# Altium schematic sheet PAGE2-ESP12_CORE.SchDoc(PAGE2-ESP12_CORE)
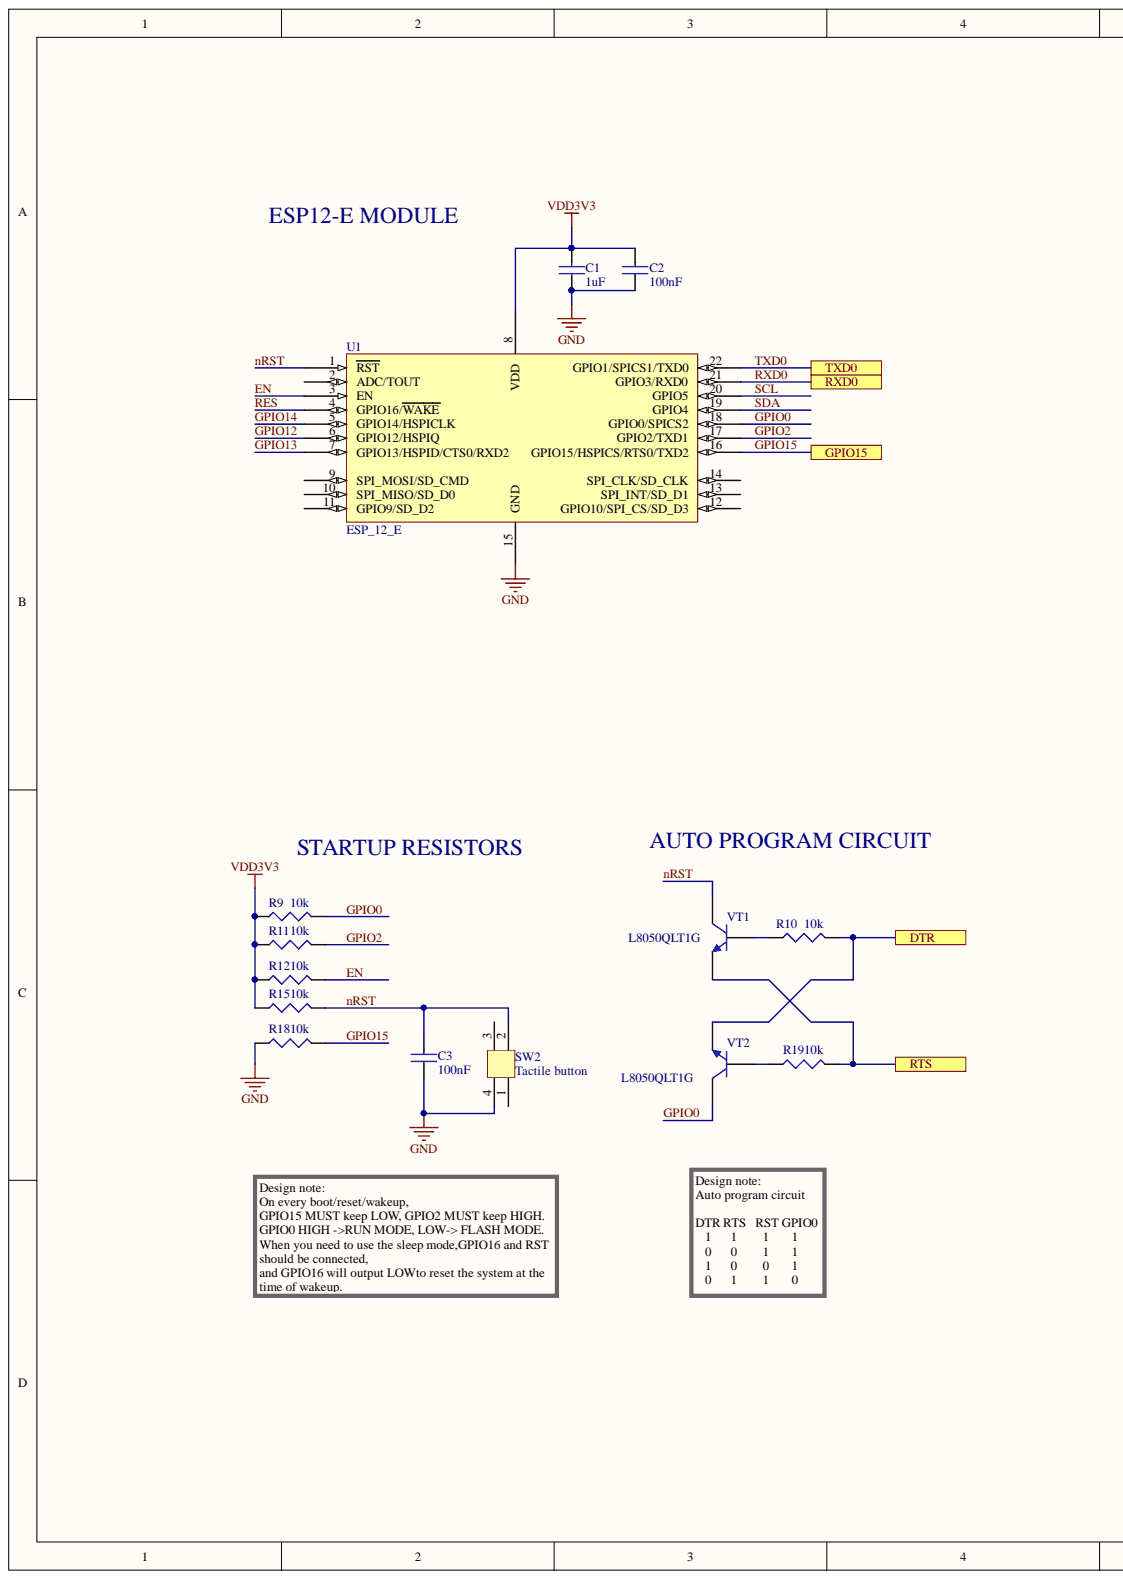
[diagram p0: PAGE2-ESP12_CORE.SchDoc, left]
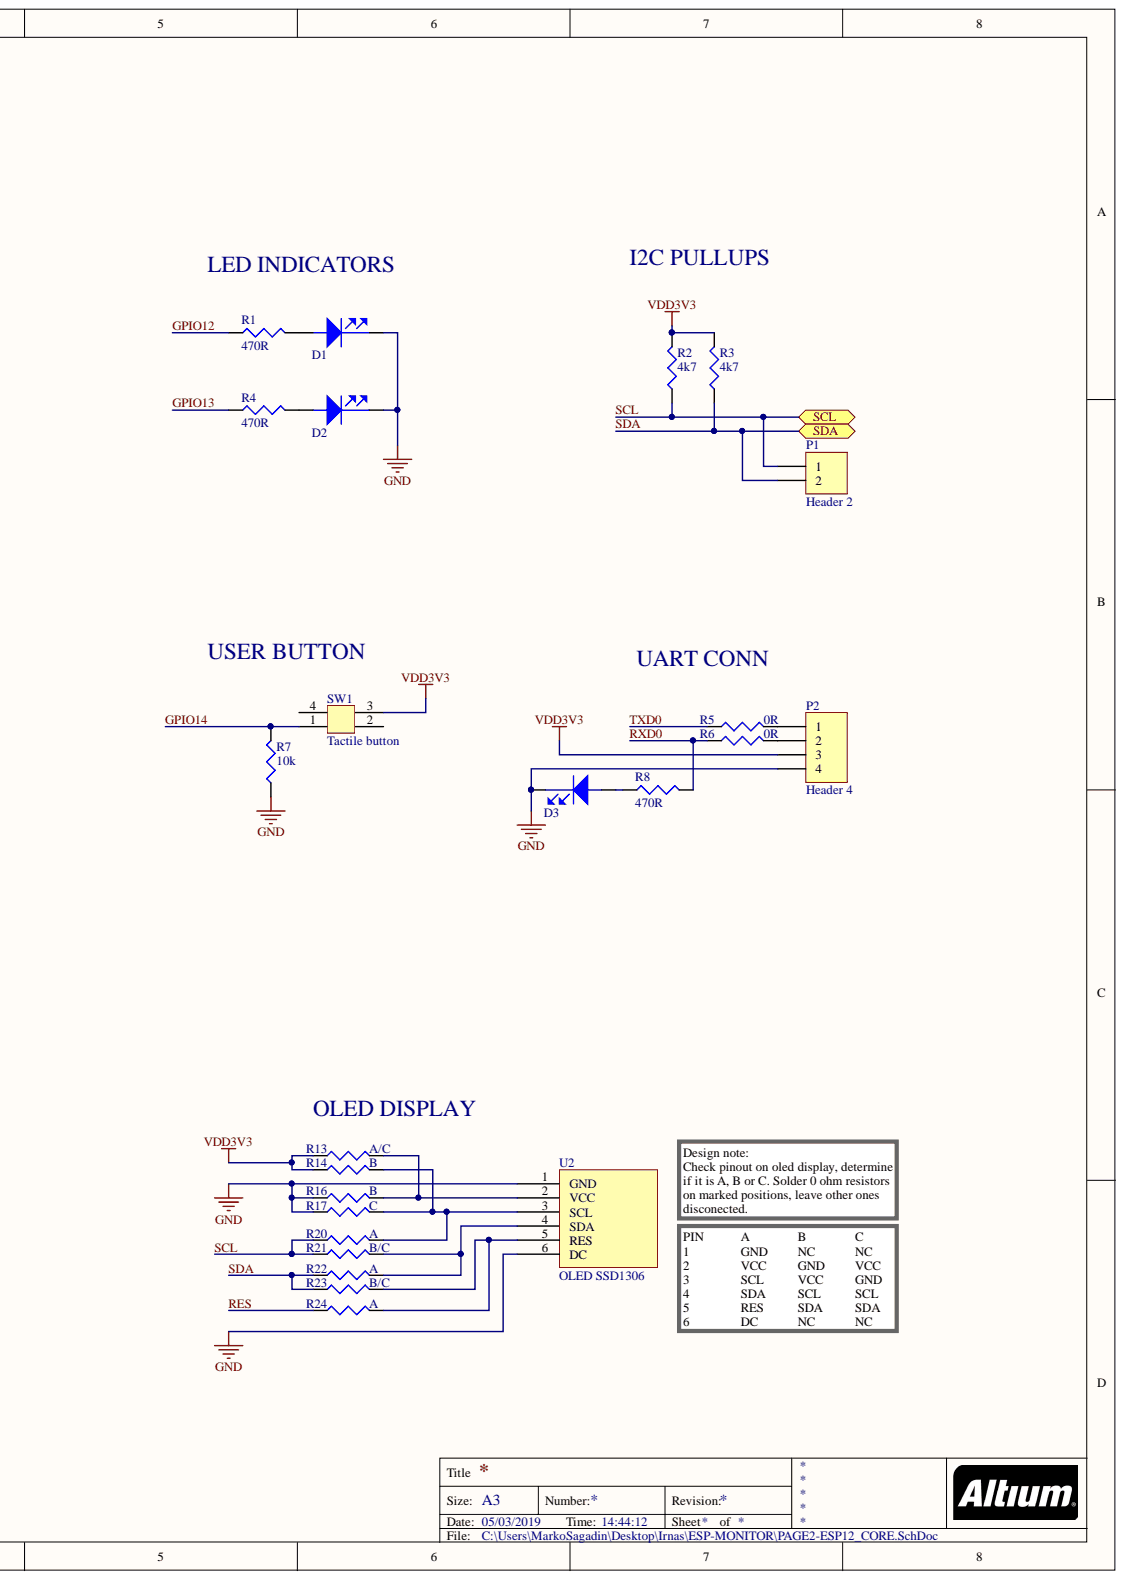
[diagram p1: PAGE2-ESP12_CORE.SchDoc, right]

## Parts
C1  1uF  [CAP0603]
C2  100nF  [CAP0603]
C3  100nF  [CAP0603]
D1  LED RED  [LED0603]  (value not drawn)
D2  LED RED  [LED0603]  (value not drawn)
D3  LED RED  [LED0603]  (value not drawn)
P1  Header 2  [HDR1X2]
P2  Header 4  [HDR1X4]
R1  470R  [RES0603]
R2  4k7  [RES0603]
R3  4k7  [RES0603]
R4  470R  [RES0603]
R5  0R  [RES0603]
R6  0R  [RES0603]
R7  10k  [RES0603]
R8  470R  [RES0603]
R9  10k  [RES0603]
R10  10k  [RES0603]
R11  10k  [RES0603]
R12  10k  [RES0603]  (value not drawn)
R13  A/C  [RES0603]
R14  B  [RES0603]
R15  10k  [RES0603]  (value not drawn)
R16  B  [RES0603]
R17  C  [RES0603]
R18  10k  [RES0603]  (value not drawn)
R19  10k  [RES0603]  (value not drawn)
R20  A  [RES0603]
R21  B/C  [RES0603]
R22  A  [RES0603]
R23  B/C  [RES0603]
R24  A  [RES0603]
SW1  Tactile button  [Tactile button]
SW2  Tactile button  [Tactile button]
U1  ESP_12_E  [ESP_12_E]
U2  OLED SSD1306  [OLED SSD1306]
VT1  L8050QLT1G  [SMT_TRIODE_NPN]
VT2  L8050QLT1G  [SMT_TRIODE_NPN]

## Ports
DTR, GPIO15, RTS, RXD0, SCL, SDA, TXD0

## Nets
GND: C1.1 C2.1 C3.1 D1.1 D2.1 D3.1 P2.4 R7.1 R16.1 R17.1 R18.1 SW2.4 U1.15(GND) U2.1(GND) U2.6(DC)
VDD3V3: C1.2 C2.2 P2.3 R2.2 R3.2 R9.1 R11.1 R12.1 R13.1 R14.1 R15.1 SW1.3 U1.8(VDD)
DTR: R10.2 VT2.2 ; port DTR
EN: R12.2 U1.3(EN)
GPIO0: R9.2 U1.18(GPIO0/SPICS2) VT2.3
GPIO2: R11.2 U1.17(GPIO2/TXD1)
GPIO12: R1.1 U1.6(GPIO12/HSPIQ)
GPIO13: R4.1 U1.7(GPIO13/HSPID/CTS0/RXD2)
GPIO14: R7.2 SW1.1 U1.5(GPIO14/HSPICLK)
GPIO15: R18.2 U1.16(GPIO15/HSPICS/RTS0/TXD2) ; port GPIO15
nRST: C3.2 R15.2 SW2.2 U1.1(RST) VT1.3
RES: R24.1 U1.4(GPIO16/WAKE)
RTS: R19.2 VT1.2 ; port RTS
RXD0: R6.1 R8.2 U1.21(GPIO3/RXD0) ; port RXD0
SCL: P1.1 R2.1 R20.1 R21.1 U1.20(GPIO5) ; port SCL
SDA: P1.2 R3.1 R22.1 R23.1 U1.19(GPIO4) ; port SDA
TXD0: R5.1 U1.22(GPIO1/SPICS1/TXD0) ; port TXD0
NetD1_2: D1.2 R1.2
NetD2_2: D2.2 R4.2
NetD3_2: D3.2 R8.1
NetP2_1: P2.1 R5.2
NetP2_2: P2.2 R6.2
NetR10_1: R10.1 VT1.1
NetR13_2: R13.2 R16.2 U2.2(VCC)
NetR14_2: R14.2 R17.2 R20.2 U2.3(SCL)
NetR19_1: R19.1 VT2.1
NetR21_2: R21.2 R22.2 U2.4(SDA)
NetR23_2: R23.2 R24.2 U2.5(RES)

Not connected: SW1 2 4; SW2 1 3; U1 2(ADC/TOUT) 9(SPI_MOSI/SD_CMD) 10(SPI_MISO/SD_D0) 11(GPIO9/SD_D2) 12(GPIO10/SPI_CS/SD_D3) 13(SPI_INT/SD_D1) 14(SPI_CLK/SD_CLK)

## Library data of the parts
C1 = 1uF: MURATA - GRM188R61H105KAALD - CAP, MLCC, X5R, 1UF, 50V, 0603; Voltage Rating: 50; SVHC: No SVHC (17-Dec-2015); Product Range: GRM Series; Dielectric Characteristic: X5; Ceramic Capacitor Case: 0603 [1608 Metric]; Capacitor Terminals: SMD<!--FANDB--><div class="features-and-benefits-container"><div class="features-and-benefits-description"><span class="features-benefits-value">The Murata GRM series capacitors are Multilayer Ceramic Chip Capacitors (MLCC) used for general purpose applications. These MLCC capacitors are classified into temperature compensating and high dielectric constant types depending on the change in electrostatic capacitance due to change in temperature. The temperature compensating capacitors feature a small rate of change in electrostatic capacitance as the temperature changes and are used for applications such as filters and high frequency circuit matching. The high dielectric constant capacitors use materials with a high dielectric constant and feature a large electrostatic capacitance. Typical applications are power supply decoupling and smoothing circuits.</span></div><div class="features-and-benefits-list"><ul class="features-and-benefits-list-list"><li class="features-and-benefits-list-item">Large capacity and small size in a multilayer structure</li><li class="features-and-benefits-list-item">Capacitance value ranging from 0.1pF to 220?F</li><li class="features-and-benefits-list-item">Tin plated external electrodes, excellent solderability</li><li class="features-and-benefits-list-item">Provides good high frequency characteristics</li><li class="features-and-benefits-list-item">Excellent pulse response</li><li class="features-and-benefits-list-item">High reliability</li><li class="features-and-benefits-list-item">Capacitor size varies from 0.4×0.2mm to 5.7×5.0mm</li><li class="features-and-benefits-list-item">Rated voltage of 2.5VDC to 3.15; Capacitance Tolerance ±: ± 10%; Capacitance: 1; Supplier Part Number 1: 1845736; Supplier 1: Farnell; Stock 1: 62682; RoHS: YES; Pricing 1: 10=0.093, 100=0.062, 500=0.0507, 1000=0.0465, 2000=0.0429, 4000=0.0399, 20000=0.0372, 40000=0.0365 (GBP); Packaging: Cut Tape; Operating Temperature Min: -55; Operating Temperature Max: 85; MSL: -; Manufacturer Part Number: GRM188R61H105KAALD; Manufacturer: MURATA; Library Name: Capacitors.IntLib; ComponentLink1URL: http://www.farnell.com/datasheets/2048611.pdf; ComponentLink1Description: http://www.farnell.com/datasheets/2048611.pdf; Component Kind: Standard; Capacitance Tolerance: ± 10%; Automotive Qualification Standard: -
C2 C3 = 100nF: MURATA - GRM188R71C104KA01J - CAP, MLCC, X7R, 0.1UF, 16V, 0603; Voltage Rating: 16; SVHC: No SVHC (20-Jun-2016; Product Range: GRM Series; Dielectric Characteristic: X7; Ceramic Capacitor Case: 0603 [1608 Metric]; Capacitance Tolerance: ± 10%; Capacitance: 0.1; Supplier Part Number 1: 2688519; Supplier 1: Farnell; Stock 1: 18297; RoHS: YES; Pricing 1: 10=0.0145, 100=0.0126, 500=0.0081, 2500=0.0059, 5000=0.0052, 10000=0.0046, 50000=0.0036, 100000=0.0035 (GBP); Packaging: Cut Tape; Operating Temperature Min: -55; Operating Temperature Max: 125; MSL: MSL 1 - Unlimited; Manufacturer Part Number: GRM188R71C104KA01J; Manufacturer: MURATA; Library Name: Capacitors.IntLib; ComponentLink1URL: http://www.farnell.com/datasheets/2189124.pdf; ComponentLink1Description: http://www.farnell.com/datasheets/2189124.pdf; Component Kind: Standard; Automotive Qualification Standard: -
D1-D3 = LED RED: BROADCOM LIMITED - HSMS-C190 - LED, RED, 10MCD, 626NM; Wavelength Typ: 626; Viewing Angle: 170°; SVHC: No SVHC (17-Dec-2015)<!--FANDB--><div class="features-and-benefits-container"><div class="features-and-benefits-description"><span class="features-benefits-value">The HSMS-C190 is a HER SMD Chip LED with untinted/diffused lens, made of GaP. It is designed in an industry standard package 1.6 x 0.8mm footprint with 0.8mm profile. It is compatible with IR reflow solder processes. The small size and wide viewing angle make this LED prime choices for backlighting applications and front panel illumination especially where space is a premium.</span></div><div class="features-and-benefits-list"><ul class="features-and-benefits-list-list"><li class="features-and-benefits-list-item">Small size</li><li class="features-and-benefits-list-item">Industry standard footprint</li><li class="features-and-benefits-list-item">Compatible with IR solder</li><li class="features-and-benefits-list-item">Diffused optics</li><li class="features-and-benefits-list-item">-40 to 85; Luminous Intensity: 10; Lens Shape: Rectangular; LED Mounting: SMD; Forward Voltage: 2.1; Forward Current If: 20; Supplier Part Number 1: 2497356; Supplier 1: Farnell; Stock 1: 7534; RoHS: YES; Product Range: -; Pricing 1: 1=0.361, 10=0.256, 50=0.235, 100=0.106, 200=0.101 (GBP); Packaging: Cut Tape; MSL: -; Manufacturer Part Number: HSMS-C190; Manufacturer: BROADCOM LIMITED; Library Name: Diodes.IntLib; LED Colour: Red; ComponentLink3URL: http://www.farnell.com/datasheets/2165483.pdf; ComponentLink3Description: http://www.farnell.com/datasheets/2165483.pdf; ComponentLink2URL: http://www.farnell.com/datasheets/1681524.pdf; ComponentLink2Description: http://www.farnell.com/datasheets/1681524.pdf; ComponentLink1URL: http://www.farnell.com/datasheets/313031.pdf; ComponentLink1Description: http://www.farnell.com/datasheets/313031.pdf; Component Kind: Standard; Bulb Size: -; Automotive Qualification Standard: -
P1 = Header 2: Header, 2-Pin; Signal Integrity: Connector; Library Name: Miscellaneous Connectors.IntLib; LatestRevisionNote: Re-released for DXP Platform.; LatestRevisionDate: 17-Jul-2002; Component Kind: Standard; Publisher: Altium Limited
P2 = Header 4: Header, 4-Pin; Signal Integrity: Connector; Library Name: Miscellaneous Connectors.IntLib; LatestRevisionNote: Re-released for DXP Platform.; LatestRevisionDate: 17-Jul-2002; Component Kind: Standard; Publisher: Altium Limited
R1 R4 R8 = 470R: MULTICOMP - MCMR06X471 JTL - RES, CERAMIC, 470R, 5%, 0.1W, 0603; Supplier Part Number 1: 2073513; Supplier 1: Farnell; Stock 1: 3786; RoHS: Y-EX; Pricing 1: 10=0.0089, 100=0.0076, 500=0.0063, 1000=0.0049, 2500=0.0033, 5000=0.0024, 25000=0.0016, 50000=0.0013 (GBP); Manufacturer Part Number: MCMR06X471 JTL; Manufacturer: MULTICOMP; Library Name: Resistors.IntLib; ComponentLink1URL: http://www.farnell.com/datasheets/1716707.pdf; ComponentLink1Description: http://www.farnell.com/datasheets/1716707.pdf; Component Kind: Standard
R2 R3 = 4k7: MULTICOMP - MCMR06X4701FTL - RES, CERAMIC, 4K7, 1%, 0.1W, 0603; Voltage Rating: 75; Temperature Coefficient: ± 100; SVHC: No SVHC (12-Jan-2017)<!--FANDB--><div class="features-and-benefits-container"><div class="features-and-benefits-description"><span class="features-benefits-value">The Multicomp MCMR series resistors are automotive grade, anti sulphured thick film chip resistor. The resistance range includes E24 and E96 decade values from 1ohm to 10Mohm. These resistors are constructed on top of a high grade ceramic body.</span></div><div class="features-and-benefits-list"><ul class="features-and-benefits-list-list"><li class="features-and-benefits-list-item">EIA 0402, 0603, 0805, 1206 chip sizes available</li><li class="features-and-benefits-list-item">High reliability and stability</li><li class="features-and-benefits-list-item">Automotive grade AEC-Q200 compliant</li><li class="features-and-benefits-list-item">Complaint with (IEC 60068-1) 55/155/56 climatic category</li><li class="features-and-benefits-list-item">Maximum operation voltage of 50V, 75V, 150V and 200V</li><li class="features-and-benefits-list-item">Power rating of 62.5mW, 100mW, 125mW and 250mW</li><li class="features-and-benefits-list-item">Available in ±1% and ±5% tolerance</li><li class="features-and-benefits-list-item">Temperature coefficient (TCR) of +200ppm/°C, +100ppm/°C and -200ppm/°C to +400ppm/°C</li><li class="features-and-benefits-list-item">Operating temperature range -55°C to 155; Resistor Case Style: 0603 [1608 Metric]; Resistance: 4.7; Product Range: MCMR Series; Power Rating: 100; Supplier Part Number 1: 2073509; Supplier 1: Farnell; Stock 1: 218618; RoHS: Y-EX; Resistor Element Material: Ceramic; Resistance Tolerance: ± 1%; Pricing 1: 10=0.0085, 100=0.0051, 500=0.0036, 1000=0.0028, 2500=0.0023 (GBP); Packaging: Cut Tape; Manufacturer Part Number: MCMR06X4701FTL; Manufacturer: MULTICOMP; Library Name: Resistors.IntLib; ComponentLink1URL: http://www.farnell.com/datasheets/1716707.pdf; ComponentLink1Description: http://www.farnell.com/datasheets/1716707.pdf; Component Kind: Standard; Automotive Qualification Standard: -
R5 R6 R13 R14 R16 R17 R20-R24 = 0R: BOURNS - CR0603-J/-000ELF - RES, THICK FILM, 0R, 5%, 0.1W, 0603; Voltage Rating: 50; SVHC: No SVHC (17-Dec-2015); Resistor Mounting: Surface Mount Device<!--FANDB--><div class="features-and-benefits-container"><div class="features-and-benefits-description"><span class="features-benefits-value">The Bourns CR series are standard thick film chip resistors. These resistors provide flexibility of performance, space saving and low cost. The Resistance range includes E96 and E24 decade values from 1ohm to 10Mohm.</span></div><div class="features-and-benefits-list"><ul class="features-and-benefits-list-list"><li class="features-and-benefits-list-item">0201, 0402, 0603, 0805, 1206, 1210, 2010, 2512 standard case sizes available</li><li class="features-and-benefits-list-item">±1% and ±5% tolerance</li><li class="features-and-benefits-list-item">±100ppm/°C, ±200ppm/°C and ±250ppm/°C TCR values available</li><li class="features-and-benefits-list-item">Power rating selectable for 50mW, 63mW, 0.1W, 0.125W, 0.25W, 0.5W and 1W based on ordering</li><li class="features-and-benefits-list-item">Jumpers are available for all size</li><li class="features-and-benefits-list-item">3 layer termination process with nickel barrier prevents leaching & provides excellent solderability</li><li class="features-and-benefits-list-item">Suitable for all type of soldering processes</li><li class="features-and-benefits-list-item">Tight dimensional tolerances</li><li class="features-and-benefits-list-item">Maximum working voltage depends on case size</li><li class="features-and-benefits-list-item">Operating temperature of -55°C to +125°C(CR0201) and -55°C to +155; Resistor Case Style: 0603 [1608 Metric]; Resistance: 0; Product Range: CR Series; Power Rating: 100; Temperature Coefficient: -; Supplier Part Number 1: 2008343; Supplier 1: Farnell; Stock 1: 58200; RoHS: YES; Resistor Element Material: Thick Film; Resistance Tolerance: ± 5%; Pricing 1: 10=0.0146, 250=0.0097, 1000=0.0073, 5000=0.0049, 12500=0.0042 (GBP); Packaging: Cut Tape; MSL: MSL 1 - Unlimited; Manufacturer Part Number: CR0603-J/-000ELF; Manufacturer: BOURNS; Library Name: Resistors.IntLib; ComponentLink2URL: http://www.farnell.com/datasheets/2178762.pdf; ComponentLink2Description: http://www.farnell.com/datasheets/2178762.pdf; ComponentLink1URL: http://www.bourns.com/engineering/CHPREZTR/cr0603.stp; ComponentLink1Description: http://www.bourns.com/engineering/CHPREZTR/cr0603.stp; Component Kind: Standard; Automotive Qualification Standard: -
R7 R9-R12 R15 R18 R19 = 10k: MULTICOMP - MCMR06X103 JTL - RES, CERAMIC, 10K, 5%, 0.1W, 0603; Voltage Rating: 75; Temperature Coefficient: ± 100; Resistor Case Style: 0603 [1608 Metric]; Resistance: 10; Product Range: MCMR Series; Power Rating: 100; Automotive Qualification Standard: -<!--FANDB--><div class="features-and-benefits-container"><div class="features-and-benefits-description"><span class="features-benefits-value">The Multicomp MCMR series resistors are automotive grade, anti sulphured thick film chip resistor. The resistance range includes E24 and E96 decade values from 1ohm to 10Mohm. These resistors are constructed on top of a high grade ceramic body.</span></div><div class="features-and-benefits-list"><ul class="features-and-benefits-list-list"><li class="features-and-benefits-list-item">EIA 0402, 0603, 0805, 1206 chip sizes available</li><li class="features-and-benefits-list-item">High reliability and stability</li><li class="features-and-benefits-list-item">Automotive grade AEC-Q200 compliant</li><li class="features-and-benefits-list-item">Complaint with (IEC 60068-1) 55/155/56 climatic category</li><li class="features-and-benefits-list-item">Maximum operation voltage of 50V, 75V, 150V and 200V</li><li class="features-and-benefits-list-item">Power rating of 62.5mW, 100mW, 125mW and 250mW</li><li class="features-and-benefits-list-item">Available in ±1% and ±5% tolerance</li><li class="features-and-benefits-list-item">Temperature coefficient (TCR) of +200ppm/°C, +100ppm/°C and -200ppm/°C to +400ppm/°C</li><li class="features-and-benefits-list-item">Operating temperature range -55°C to 155; Supplier Part Number 1: 2073356; Supplier 1: Farnell; Stock 1: 19791; RoHS: Y-EX; Resistor Element Material: Ceramic; Resistance Tolerance: ± 5%; Pricing 1: 10=0.0087, 100=0.0074, 500=0.0061, 1000=0.0048, 2500=0.0032, 5000=0.0024, 25000=0.0016, 50000=0.0013 (GBP); Packaging: Cut Tape; Manufacturer Part Number: MCMR06X103 JTL; Manufacturer: MULTICOMP; Library Name: Resistors.IntLib; ComponentLink1URL: http://www.farnell.com/datasheets/1716707.pdf; ComponentLink1Description: http://www.farnell.com/datasheets/1716707.pdf; Component Kind: Standard
SW1 SW2 = KMR221NGLFS: SWITCH TACTILE SPST-NO 0.05A 32V; Manufacturer: ITT C&K; Switch Configuration: N.O.; Pole Throw Configuration: SPST; Operating Force: 2 N; Minimum Insulation Resistance: >=1000 MOhm; Mechanical Life: 200000 Cycles; Illumination Type: N/R; Ground Terminal: No; Dielectric Strength: >=250 Vrms; Contact Rating @ Voltage: 1 A; Body Orientation: Straight; Actuator Style: Round Button; Actuator Length: 0.5 mm; Type: Micro-Miniature; Supplier Standard Pack: 7,000; Supplier Part Number 1: 2845727; Supplier 1: C&K Components; Stock 1: 5345; Seal Location: N/A; Seal Description: N/A; RoHS: Yes; Product Weight: N/A; Pricing 1: 10=0.278, 500=0.264, 1000=0.208, 5000=0.204, 10000=0.2 (GBP); Part No: KMR221NGLFS; Packaging: Tape and Reel; Operating Temperature: -40 to 85 C; Mounting: Surface Mount,Gull Wing; Maximum DC Voltage Rating: 32 V; Maximum AC Voltage Rating: N/A; Manufacturer Part Number: KMR221NGLFS; HTS: 8536501199; Housing Material: N/A; Family: KMR 2; Electrical Life: N/A; ECCN: EAR99; Dimension: 2.8 x 4.6 x 1.9 mm; Contact Plating: Silver; Contact Material: Silver; ComponentLink5URL: https://datasheet.ciiva.com/2900/kmr2-9aug12-2900171.pdf; ComponentLink5Description: https://datasheet.ciiva.com/2900/kmr2-9aug12-2900171.pdf; ComponentLink4URL: https://datasheet.ciiva.com/16695/kmr2-9aug12-16695914.pdf; ComponentLink4Description: https://datasheet.ciiva.com/16695/kmr2-9aug12-16695914.pdf; ComponentLink3URL: https://datasheet.ciiva.com/2900/kmr2-9aug12-2900170.pdf; ComponentLink3Description: https://datasheet.ciiva.com/2900/kmr2-9aug12-2900170.pdf; ComponentLink2URL: https://datasheet.ciiva.com/2900/kmr2-9aug12-2900169.pdf; ComponentLink2Description: https://datasheet.ciiva.com/2900/kmr2-9aug12-2900169.pdf; ComponentLink1URL: https://datasheet.ciiva.com/16443/kmr221nglfs-16443038.pdf; ComponentLink1Description: https://datasheet.ciiva.com/16443/kmr221nglfs-16443038.pdf; Component Kind: Standard; Base Material: N/A; Actuator Color: N/A; Library Name: EnviromentalBoard.SchLib
U1 = ESP_12_E: ESP-12 Wi-Fi Module by AI-Thinker; IC: ESP8266-EX; Vendor: Ai-thinker; Component Kind: Standard; Library Name: Vowstar_Module.SchLib
VT1 VT2 = SMT_TRIODE_NPN: Surface mount NPN transistor, package SOT-23; Component Kind: Standard; Library Name: Vowstar_Basic_Device.SchLib
U2 = OLED SSD1306: Library Name: oled.SchLib; Component Kind: Standard
